# Altium schematic sheet overview.SchDoc
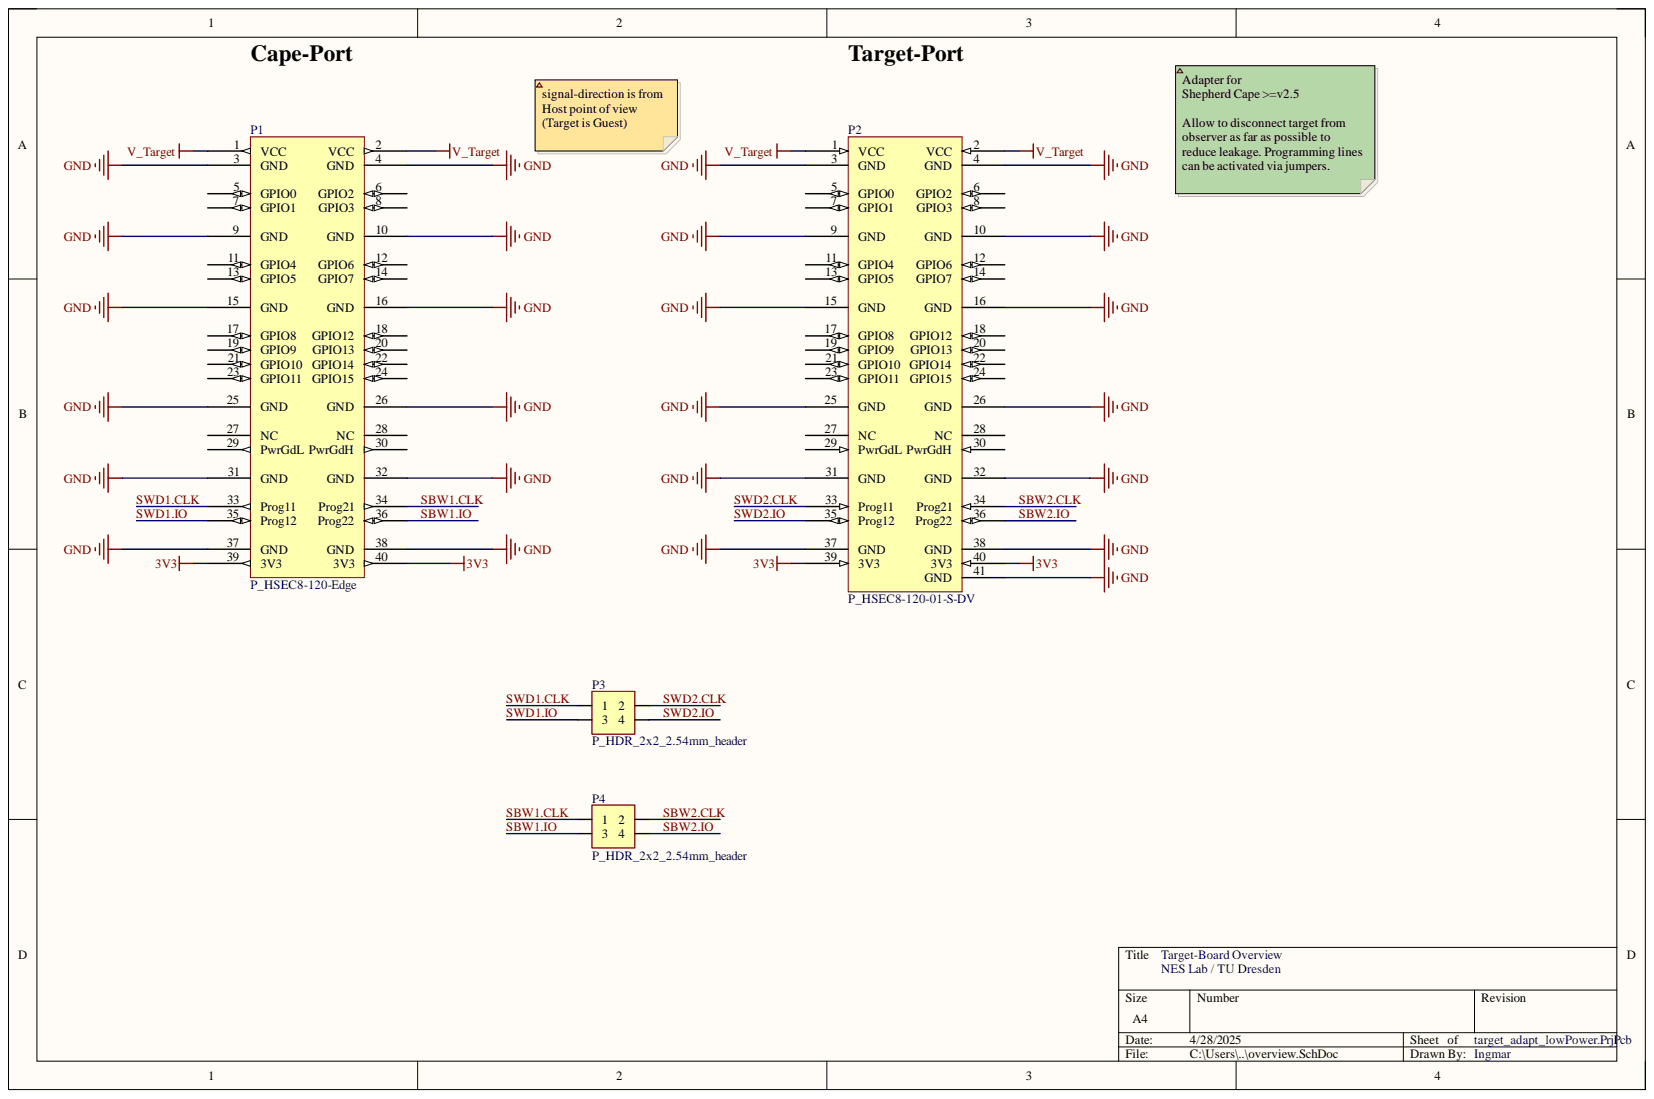
[diagram p0: overview.SchDoc]

## Parts
P1  P_HSEC8-120-Edge  [P_HSEC8-120_edge]
P2  P_HSEC8-120-01-S-DV  [P_HSEC8-120-01-S-DV]
P3  P_HDR_2x2_2.54mm_header  [P_Pinheader_2x2_R2.54mm]
P4  P_HDR_2x2_2.54mm_header  [P_Pinheader_2x2_R2.54mm]

## Nets
3V3: P1.39(3V3) P1.40(3V3) P2.39(3V3) P2.40(3V3)
GND: P1.3(GND) P1.4(GND) P1.9(GND) P1.10(GND) P1.15(GND) P1.16(GND) P1.25(GND) P1.26(GND) P1.31(GND) P1.32(GND) P1.37(GND) P1.38(GND) P2.3(GND) P2.4(GND) P2.9(GND) P2.10(GND) P2.15(GND) P2.16(GND) P2.25(GND) P2.26(GND) P2.31(GND) P2.32(GND) P2.37(GND) P2.38(GND) P2.41(GND)
V_Target: P1.1(VCC) P1.2(VCC) P2.1(VCC) P2.2(VCC)
SBW1.CLK: P1.34(Prog21) P4.1
SBW1.IO: P1.36(Prog22) P4.3
SBW2.CLK: P2.34(Prog21) P4.2
SBW2.IO: P2.36(Prog22) P4.4
SWD1.CLK: P1.33(Prog11) P3.1
SWD1.IO: P1.35(Prog12) P3.3
SWD2.CLK: P2.33(Prog11) P3.2
SWD2.IO: P2.35(Prog12) P3.4

Not connected: P1 5(GPIO0) 6(GPIO2) 7(GPIO1) 8(GPIO3) 11(GPIO4) 12(GPIO6) 13(GPIO5) 14(GPIO7) 17(GPIO8) 18(GPIO12) 19(GPIO9) 20(GPIO13) 21(GPIO10) 22(GPIO14) 23(GPIO11) 24(GPIO15) 27(NC) 28(NC) 29(PwrGdL) 30(PwrGdH); P2 5(GPIO0) 6(GPIO2) 7(GPIO1) 8(GPIO3) 11(GPIO4) 12(GPIO6) 13(GPIO5) 14(GPIO7) 17(GPIO8) 18(GPIO12) 19(GPIO9) 20(GPIO13) 21(GPIO10) 22(GPIO14) 23(GPIO11) 24(GPIO15) 27(NC) 28(NC) 29(PwrGdL) 30(PwrGdH)

## Library data of the parts
P1 = .P_HSEC8-120-Edge: Gold, Vertical, EdgeConnector, 2 Row, .8mm distance; Manufacturer: Samtec; I_max: 2.8A; V_max: 240V; Component Kind: Standard; Distributer: Mouser; Library Name: shepherd_parts.SchLib
P2 = .P_HSEC8-120-01-S-DV: Gold, Vertical, EdgeConnector, 2 Row, .8mm distance; Manufacturer: Samtec; Manufacturer PN: HSEC8-120-01-L-DV-A-K-TR; I_max: 2.8A; V_max: 240V; Component Kind: Standard; Distributer: Mouser; Distributer PN: 200-HSEC812001LDVAKT; Price n10 [€]: 3.17; Library Name: shepherd_parts.SchLib
P3 P4 = .P_HDR_2x2_2.54mm_male: Gold, Straight, 5.80 mm Mating, 3.46 mm termination; Manufacturer: Amphenol; Manufacturer PN: 861400042YO2319LF; I_max: 3A; V_max: 1kV; Component Kind: Standard; Distributer: Mouser; Distributer PN: 649-86140042YO2319LF; Price n10 [€]: 0.12; Library Name: shepherd_parts.SchLib
